# Altium schematic sheet Schematic1.SchDoc(Schematic1)
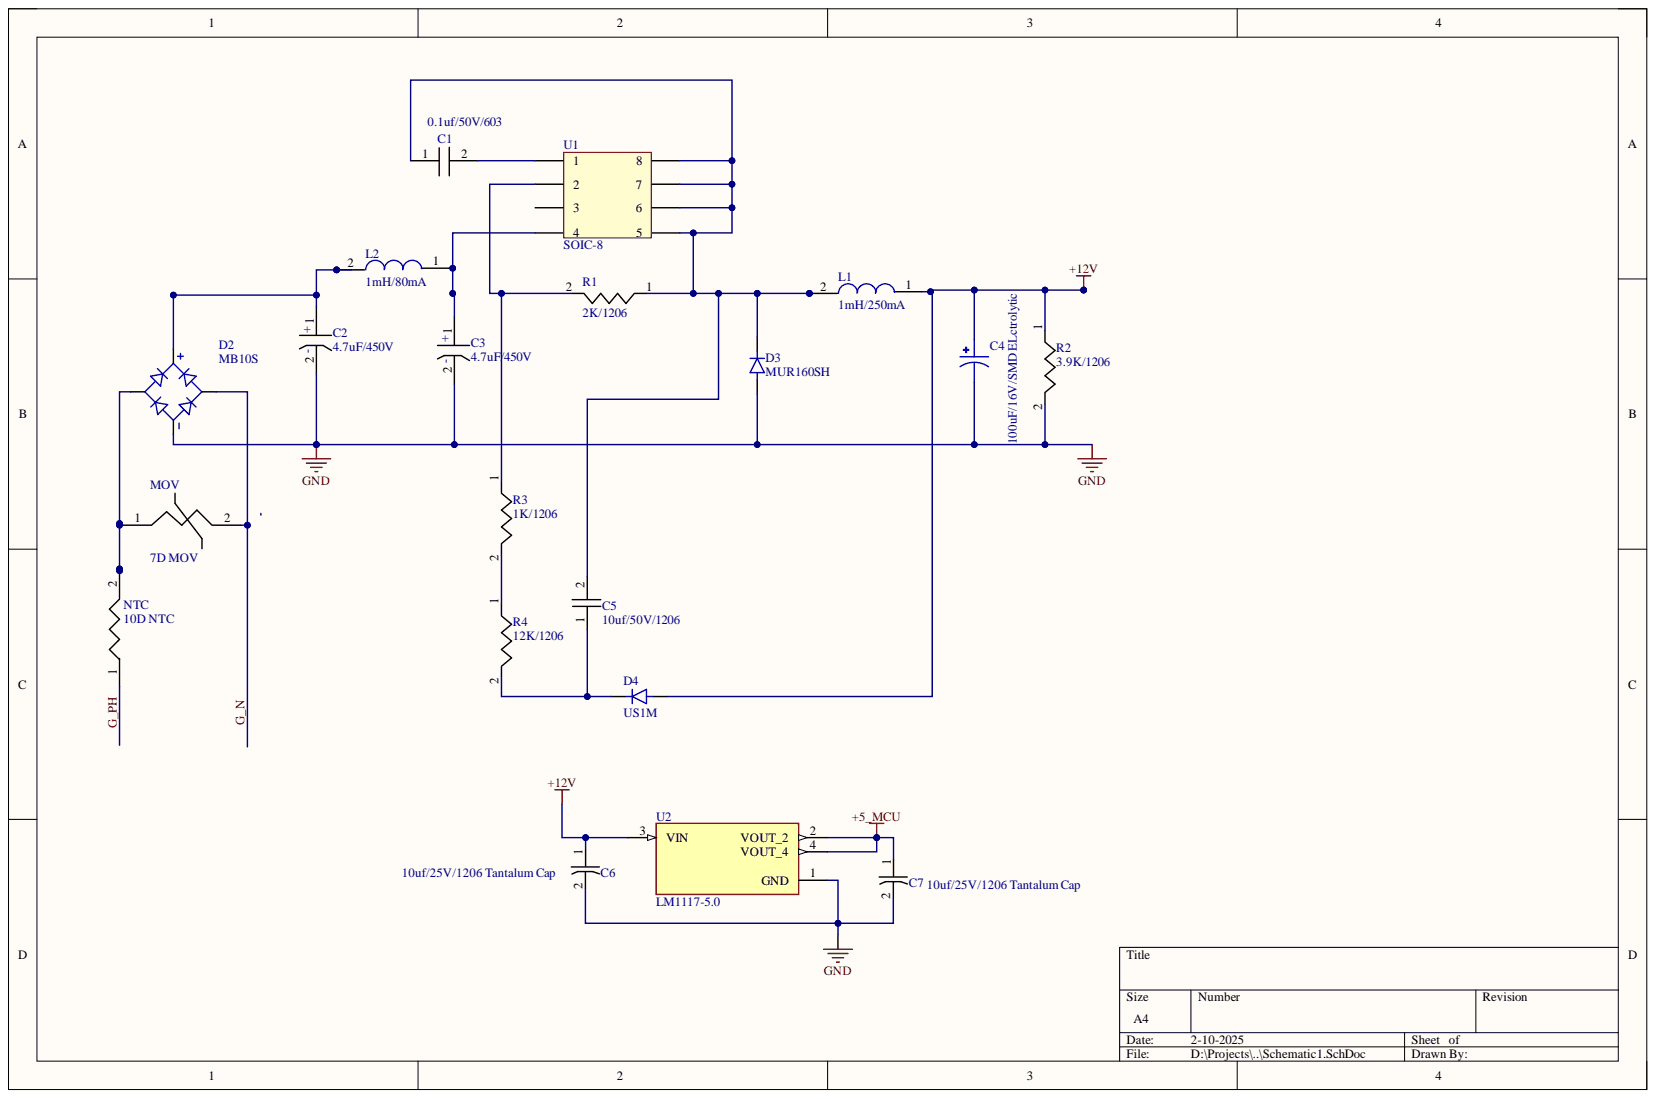
[diagram p0: Schematic1.SchDoc]

## Parts
C1  0.1uf/50V/603  [603]
C2  4.7uF/450V  [8mm Dia Eletrolytic Cap]
C3  4.7uF/450V  [8mm Dia Eletrolytic Cap]
C4  100uF/16V/SMD ELctrolytic  [CAP_865080645012]
C5  10uf/50V/1206  [1206]
C6  10uf/25V/1206 Tantalum Cap  [1206 POL CAP]
C7  10uf/25V/1206 Tantalum Cap  [1206 POL CAP]
D2  MB10S  [SOIC245P670X290-4N]
D3  MUR160SH  [DIOM4336X265N]
D4  US1M  [DIOM4336X265N]
L1  1mH/250mA  [R10 Inductor Footprint]
L2  1mH/80mA  [R10 Inductor Footprint]
MOV  7D MOV  [5mm pitch 4mm thick component]
NTC  10D NTC  [5mm pitch 4mm thick component]
R1  2K/1206  [1206]
R2  3.9K/1206  [1206]
R3  1K/1206  [1206]
R4  12K/1206  [1206]
U1  SOIC-8  [SOIC127P600X265-8N]
U2  LM1117-5.0  [VREG_LM1117MPX-3.3]

## Nets
+5_MCU: C7.1 U2.2(VOUT_2) U2.4(VOUT_4)
+12V: C4.1 C6.1 D4.A L1.1 R2.1 U2.3(VIN)
GND: C2.2 C3.2 C4.2 C6.2 C7.2 D2.2 D3.A R2.2 U2.1(GND)
G_N: D2.4 MOV.2
G_PH: NTC.1
NetC1_1: C1.1 C5.2 D3.C L1.2 R1.1 U1.5 U1.6 U1.7 U1.8
NetC1_2: C1.2 U1.1
NetC2_1: C2.1 D2.1 L2.2
NetC3_1: C3.1 L2.1 U1.4
NetC5_1: C5.1 D4.C R4.2
NetD2_3: D2.3 MOV.1 NTC.2
NetR1_2: R1.2 R3.1 U1.2
NetR3_2: R3.2 R4.1

Not connected: U1 3

## Library data of the parts
C1 = 603 SMD CAP: Component Kind: Standard; Library Name: Custom1.IntLib
C2 C3 = 8 Dia Cap: Component Kind: Standard; Library Name: Custom1.IntLib
C4 = 865080645012: Smd Aluminium Electrolytic Capacitor, Wcap-Asli Series, 47 F, 50 V, Radial Can - Smd, 6.3 Mm; MF: Wurth Electronics; Check_prices: https://www.snapeda.com/parts/865080645012/Wurth+Electronics+Inc./view-part/?ref=eda; Component Kind: Standard; Library Name: 865080645012.IntLib; MP: 865080645012; Package: Radial WÃ¼rth Elektronik; SnapEDA_Link: https://www.snapeda.com/parts/865080645012/Wurth+Electronics+Inc./view-part/?ref=snap
C5 = 1206 NON-POL CAP: Component Kind: Standard; Library Name: Custom1.IntLib
C6 C7 = 1206 POL CAP: Component Kind: Standard; Library Name: Custom1.IntLib
D2 = MB10S: Bridge Rectifier Single Phase Standard 1 kV Surface Mount 4-SOIC; MF: ON Semiconductor; Availability: In Stock; Check_prices: https://www.snapeda.com/parts/MB10S/Onsemi/view-part/?ref=eda; Component Kind: Standard; Library Name: MB10S.IntLib; MP: MB10S; Package: SOIC W-4 ON Semiconductor; Price: None; Purchase-URL: https://pricing.snapeda.com/search/part/MB10S/?ref=eda; SnapEDA_Link: https://www.snapeda.com/parts/MB10S/Onsemi/view-part/?ref=snap
D4 = MUR160SH: Availability: Unavailable; Component Kind: Standard; Library Name: MUR160SH.IntLib; MP: MUR160SH; Package: None; Price: None
L1 L2 = R10 Drum Inductor: Component Kind: Standard; Library Name: Custom1.IntLib
NTC = NTC 10D: Component Kind: Standard; Library Name: Custom1.IntLib
R1-R4 = 1206 Resistor: Component Kind: Standard; Library Name: Custom1.IntLib
U1 = LNK304DG: Component Kind: Standard; Library Name: Custom1.IntLib
U2 = LM1117MPX-3.3: 800-mA 15-V linear voltage regulator; MF: Texas Instruments; Availability: In Stock; Check_prices: https://www.snapeda.com/parts/LM1117MPX-3.3/Texas+Instruments/view-part/?ref=eda; Component Kind: Standard; Library Name: LM1117MPX-3.3.IntLib; MP: LM1117MPX-3.3; Package: SOT-223-4 Texas Instruments; Price: None; Purchase-URL: https://pricing.snapeda.com/search/part/LM1117MPX-3.3/?ref=eda; SnapEDA_Link: https://www.snapeda.com/parts/LM1117MPX-3.3/Texas+Instruments/view-part/?ref=snap
D3 = MUR160SH: Availability: Unavailable; Component Kind: Standard; Library Name: MUR160SH.IntLib; MP: MUR160SH; Package: None; Price: None
MOV = 7D MOV: Component Kind: Standard; Library Name: Custom1.IntLib
